# Altium schematic sheet Tiresias_PMIC.SchDoc
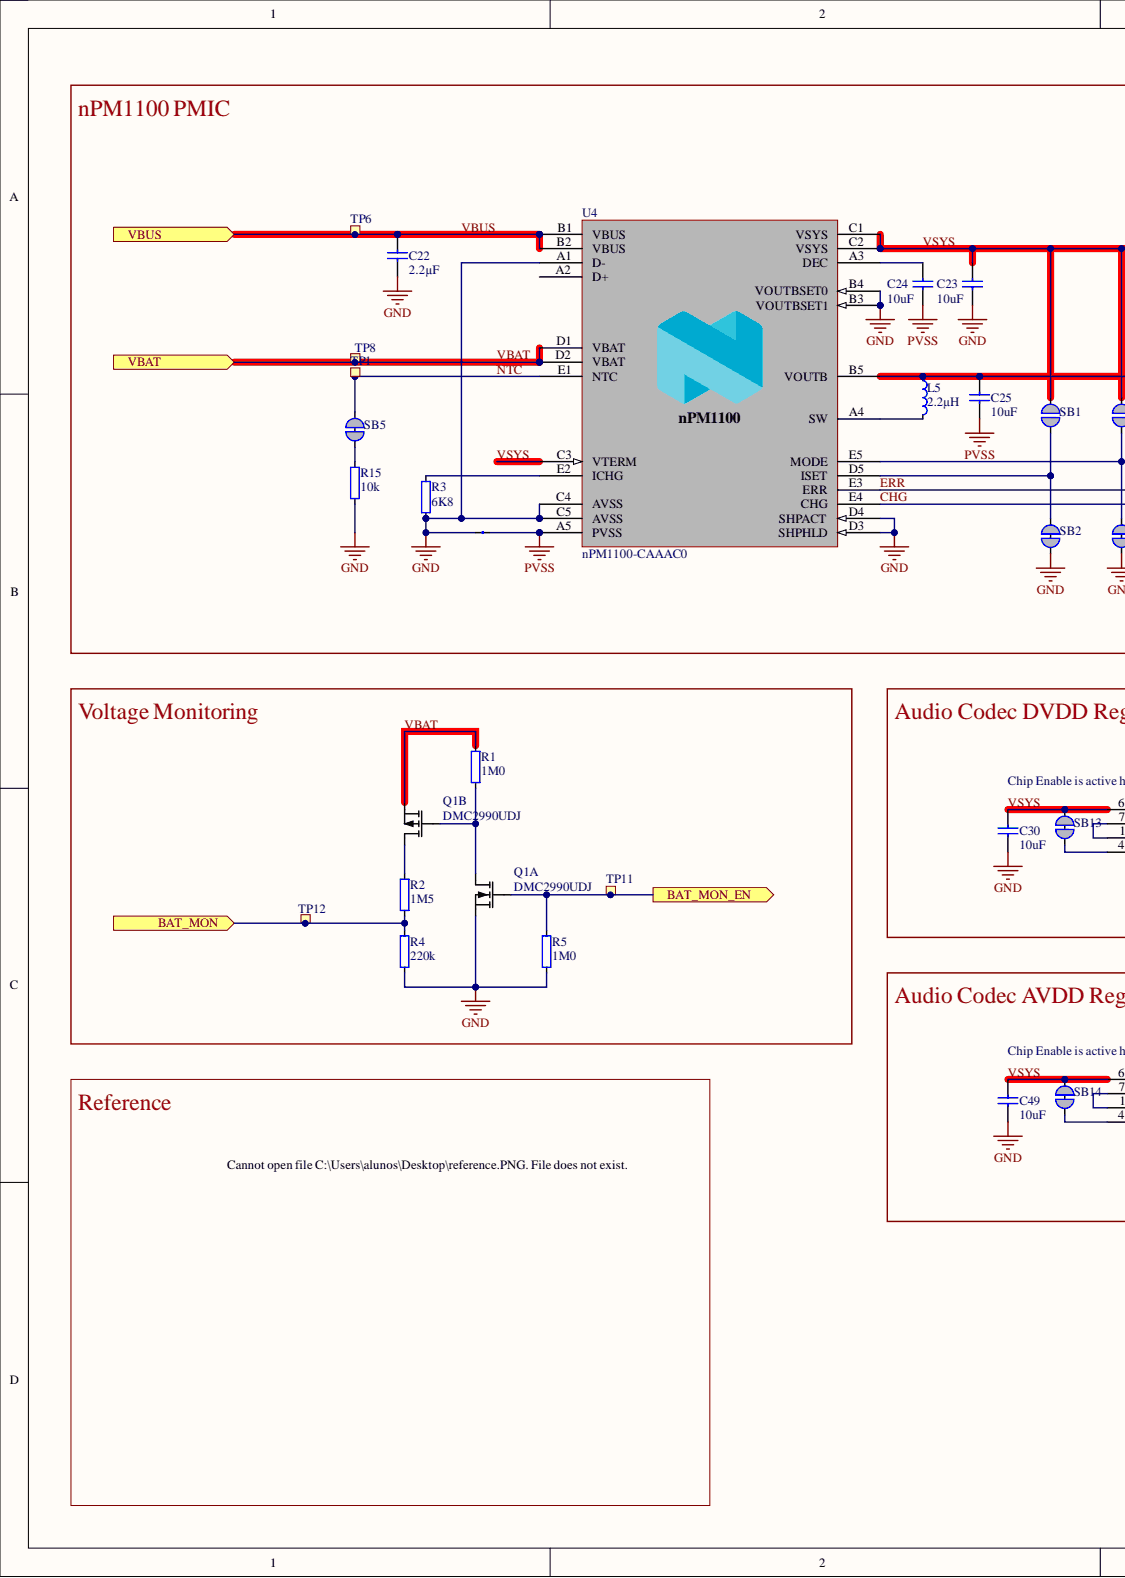
[diagram p0: Tiresias_PMIC.SchDoc, left]
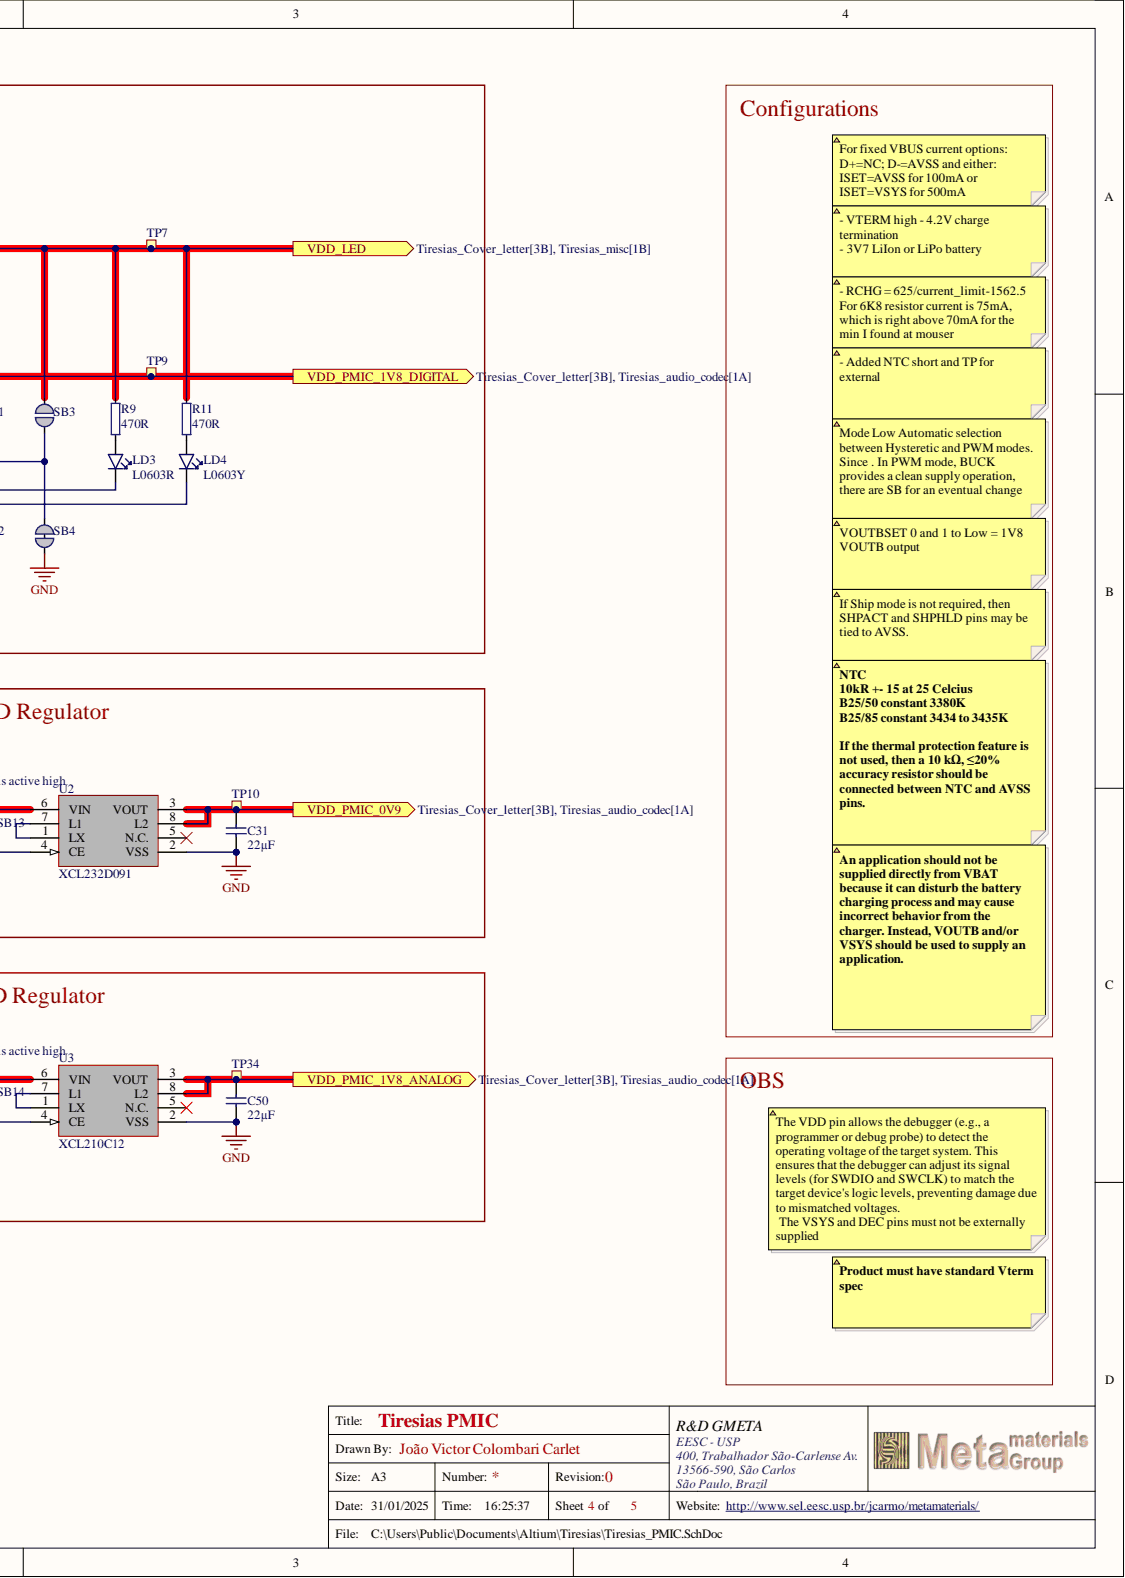
[diagram p1: Tiresias_PMIC.SchDoc, right]

## Parts
C22  2.2µF  [CAPC1608X06L]
C23  10uF  [CAPC1608X06L]
C24  10uF  [CAPC1608X06L]
C25  10uF  [CAPC1608X06L]
C30  10uF  [CAPC1608X06L]
C31  22µF  [CAPC1608X06L]
C49  10uF  [CAPC1608X06L]
C50  22µF  [CAPC1608X06L]
J6  N.A.  [2 PIN STARPOINT]  (value not drawn)
L5  2.2µH  [INDC2016X10N]
LD3  L0603R  [LED_0603_R]
LD4  L0603Y  [LED_0603_Y]
Q1  DMC2990UDJ  [SOTFL35P100X50-6N]  (parts A,B)
R1  1M0  [RESC0603X03L_C]
R2  1M5  [RESC0603X03L_C]
R3  6K8  [RESC0603X03L_C]
R4  220k  [RESC0603X03L_C]
R5  1M0  [RESC0603X03L_C]
R9  470R  [RESC0603X03L_C]
R11  470R  [RESC0603X03L_C]
R15  10k  [RESC0603X03L_C]
SB1  Solderbridge  [Solderbridge]  (value not drawn)  (no BOM)
SB2  Solderbridge  [Solderbridge_Shorted]  (value not drawn)
SB3  Solderbridge  [Solderbridge]  (value not drawn)  (no BOM)
SB4  Solderbridge  [Solderbridge_Shorted]  (value not drawn)
SB5  Solderbridge  [Solderbridge_Shorted]  (value not drawn)
SB13  Solderbridge  [Solderbridge_Shorted]  (value not drawn)
SB14  Solderbridge  [Solderbridge_Shorted]  (value not drawn)
TP1  Ø1.5mm,SMD  [TP_SMD_D1.5mm]  (value not drawn)  (no BOM)
TP6  Ø1.5mm,SMD  [TP_SMD_D1.5mm]  (value not drawn)  (no BOM)
TP7  Ø1.5mm,SMD  [TP_SMD_D1.5mm]  (value not drawn)  (no BOM)
TP8  Ø1.5mm,SMD  [TP_SMD_D1.5mm]  (value not drawn)  (no BOM)
TP9  Ø1.5mm,SMD  [TP_SMD_D1.5mm]  (value not drawn)  (no BOM)
TP10  Ø1.5mm,SMD  [TP_SMD_D1.5mm]  (value not drawn)  (no BOM)
TP11  Ø1.0mm,SMD  [TP_SMD_D1.0mm]  (value not drawn)  (no BOM)
TP12  Ø1.0mm,SMD  [TP_SMD_D1.0mm]  (value not drawn)  (no BOM)
TP34  Ø1.5mm,SMD  [TP_SMD_D1.5mm]  (value not drawn)  (no BOM)
U2  XCL232D091  [CL-2025]
U3  XCL210C12  [CL-2025]
U4  nPM1100-CAAAC0  [BGA25C40P5X5_200X200X50]

## Ports
BAT_MON, BAT_MON_EN, VBAT, VBUS, VDD_LED, VDD_PMIC_0V9, VDD_PMIC_1V8_ANALOG, VDD_PMIC_1V8_DIGITAL

## Nets
GND: C22.1 C23.1 C30.1 C31.1 C49.1 C50.1 J6.1 Q1.1 R3.2 R4.1 R5.1 R15.1 SB2.2 SB4.2 U2.2(VSS) U2.9 U3.2(VSS) U3.9 U4.A1(D-) U4.B3(VOUTBSET1) U4.B4(VOUTBSET0) U4.C4(AVSS) U4.C5(AVSS) U4.D3(SHPHLD) U4.D4(SHPACT)
PVSS: C24.1 C25.2 J6.2 U4.A5(PVSS)
BAT_MON: R2.1 R4.2 TP12.1 ; port BAT_MON
BAT_MON_EN: Q1.2 R5.2 TP11.1 ; port BAT_MON_EN
CHG: LD4.1 U4.E4(CHG)
ERR: LD3.1 U4.E3(ERR)
NTC: SB5.1 TP1.1 U4.E1(NTC)
VBAT: Q1.4 R1.2 TP8.1 U4.D1(VBAT) U4.D2(VBAT) ; port VBAT
VBUS: C22.2 TP6.1 U4.B1(VBUS) U4.B2(VBUS) ; port VBUS
VDD_PMIC_0V9: C31.2 TP10.1 U2.3(VOUT) U2.8(L2) ; port VDD_PMIC_0V9
VDD_PMIC_1V8_ANALOG: C50.2 TP34.1 U3.3(VOUT) U3.8(L2) ; port VDD_PMIC_1V8_ANALOG
VDD_PMIC_1V8_DIGITAL: C25.1 L5.1 TP9.1 U4.B5(VOUTB) ; port VDD_PMIC_1V8_DIGITAL
VSYS: C23.2 C30.2 C49.2 R9.2 R11.2 SB1.1 SB3.1 SB13.1 SB14.1 TP7.1 U2.6(VIN) U3.6(VIN) U4.C1(VSYS) U4.C2(VSYS) U4.C3(VTERM) ; port VDD_LED
NetC24_2: C24.2 U4.A3(DEC)
NetL5_2: L5.2 U4.A4(SW)
NetLD3_2: LD3.2 R9.1
NetLD4_2: LD4.2 R11.1
NetQ1_3: Q1.3 R2.2
NetQ1_5: Q1.5 Q1.6 R1.1
NetR3_1: R3.1 U4.E2(ICHG)
NetR15_2: R15.2 SB5.2
NetSB1_2: SB1.2 SB2.1 U4.D5(ISET)
NetSB3_2: SB3.2 SB4.1 U4.E5(MODE)
NetSB13_2: SB13.2 U2.4(CE)
NetSB14_2: SB14.2 U3.4(CE)
NetU2_1: U2.1(LX) U2.7(L1)
NetU2_5: U2.5(N.C.)
NetU3_1: U3.1(LX) U3.7(L1)
NetU3_5: U3.5(N.C.)
NetU4_A2: U4.A2(D+)

## Library data of the parts
C22 = CAP_0603_2u2F: Capacitor, Ceramic, X5R, ±10%, 10V; Part Number: C0603-2µ2-10V-X5R; Artikkelnr Noca: N.A.; Pad Count: 2; Component Class: Capacitors; Component Kind: Standard; ComponentLink1Description: Datasheet; ComponentLink1URL: N.A.; ComponentLink2Description: Supplier link; ComponentLink2URL: N.A.; Footprint Doc: 0603; HelpURL: N.A.; LatestRevisionDate: 2021-07-22; Manufacturer: N.A.; Manufacturer Part Number: N.A.; Note: 10V; Part number IPS: N.A.; Part number Norautron: N.A.; Published: 2021-07-22; Supplier 1: N.A.; Supplier 2: N.A.; Supplier Part Number 1: N.A.; Supplier Part Number 2: N.A.; Value: 2.2µF; Author: rubr; Library Name: Tiresias_passive.SchLib
C23-C25 C30 C49 = CAP_0603_10uF: Capacitor, X5R, ±10%; Part Number: C0603-10µ; Class: SMT; Component Kind: Standard; LatestRevisionDate: 2024/02/20; Package: 0603; Pin Count: 2; Value: 10uF; Library Name: Tiresias_passive.SchLib
C31 C50 = CAP_0603_22uF: Capacitor, X5R, ±20%, 6.3V; Part Number: C0603-22µ; Artikkelnr Noca: N.A.; Pad Count: 2; Component Class: Capacitors; Component Kind: Standard; ComponentLink1Description: Datasheet; ComponentLink1URL: N.A.; ComponentLink2Description: Supplier link; ComponentLink2URL: N.A.; Footprint Doc: 0603; HelpURL: N.A.; LatestRevisionDate: 2020-09-23; Manufacturer: N.A.; Manufacturer Part Number: N.A.; Note: 6.3V; Part number IPS: N.A.; Part number Norautron: N.A.; PartId: 100200276; Published: 2020-09-23; Supplier 1: N.A.; Supplier 2: N.A.; Supplier Part Number 1: N.A.; Supplier Part Number 2: N.A.; Value: 22µF; Author: rsk; Library Name: Tiresias_passive.SchLib
J6 = 2 pin starpoint: Starpoint; Pad Count: 2; Artikkelnr Noca: N.A.; Component Class: Connectors; Component Kind: Net Tie; ComponentLink1Description: Datasheet; ComponentLink1URL: N.A.; ComponentLink2Description: Supplier link; ComponentLink2URL: N.A.; Footprint Doc: N.A.; HelpURL: N.A.; LatestRevisionDate: 2017-08-31; Manufacturer: N.A.; Manufacturer Part Number: N.A.; Note: N.A.; Part Number: 2 pin starpoint; Part number IPS: N.A.; Part number Norautron: N.A.; PartId: 100500603; Published: 2017-08-31; Supplier 1: N.A.; Supplier 2: N.A.; Supplier Part Number 1: N.A.; Supplier Part Number 2: N.A.; Value: N.A.; Author: rsk; Library Name: Tiresias_passive.SchLib
L5 = IND_0806_2u2H: FIXED IND 2.2UH 2.5A 87MOHM SMD; Manufacturer: Samsung Electro-Mechanics; Manufacturer Part Number: CIGT201610EH2R2MNE; Artikkelnr Noca: N.A.; Note: 2.2µH Unshielded Thin Film Inductor 2.5A 87mOhm Max 0806 (2016 Metric); Pad Count: 2; Component Class: Inductors; Component Kind: Standard; ComponentLink1Description: Datasheet; ComponentLink1URL: https://media.digikey.com/pdf/Data%20Sheets/Samsung%20PDFs/CIGT201610EH2R2MNE_Spec.pdf; ComponentLink2Description: Supplier link; ComponentLink2URL: https://www.digikey.com/en/products/detail/samsung-electro-mechanics/CIGT201610EH2R2MNE/7041223?s=N4IgTCBcDaIMIEkDiAVMAGAjANk%2BgogBJgBKYAsgHL4gC6AvkA; Footprint Doc: 0806; HelpURL: http://product.samsungsem.com/pi/CIGT201610EH2R2MN.do; LatestRevisionDate: 2021-02-03; Part Number: CIGT201610EH2R2MNE; Part number IPS: N.A.; Part number Norautron: N.A.; PartId: 100300400; Published: 2021-02-03; Supplier 1: Digi-Key; Supplier 2: Mouser; Supplier Part Number 1: 1276-6901-1-ND; Supplier Part Number 2: 187-CIGT201610EH2R2M; Value: 2.2µH; Author: rsk; Library Name: Tiresias_passive.SchLib
LD3 = LED_0603_RED: LED, SMD, 0603, RED; Manufacturer: Multicomp; Part Number: OVS-0608 RED; Artikkelnr Noca: N.A.; Note: 100 mcd @ 20 mA, Super bright; Pad Count: 2; Component Class: Optoelectronics; Component Kind: Standard; ComponentLink1Description: Datasheet; ComponentLink1URL: N.A.; ComponentLink2Description: Supplier link; ComponentLink2URL: N.A.; Footprint Doc: 0603; HelpURL: http://www.farnell.com/datasheets/1673654.pdf; LatestRevisionDate: 2011-10-28; Manufacturer Part Number: OVS-0608; Part number IPS: N.A.; Part number Norautron: N.A.; PartId: 101400024; Published: 2011-10-28; Supplier 1: Farnell; Supplier 2: N.A.; Supplier Part Number 1: 171-6774; Supplier Part Number 2: N.A.; Value: L0603R; Author: rsk; Library Name: Tiresias_passive.SchLib
LD4 = LED_0603_YEL: LED, Yellow, 0603, 590nm, Vf=2.0V, 120mcd, - 40 to +85°C; Manufacturer: Wurth Elektronik; Manufacturer Part Number: 150060YS75000; Artikkelnr Noca: N.A.; Pad Count: 2; Component Class: Optoelectronics; Component Kind: Standard; ComponentLink1Description: Datasheet; ComponentLink1URL: https://katalog.we-online.de/led/datasheet/150060YS75000.pdf; ComponentLink2Description: Supplier link; ComponentLink2URL: https://www.digikey.com/en/products/detail/w%C3%BCrth-elektronik/150060YS75000/4489909; Footprint Doc: 0603; HelpURL: N.A.; LatestRevisionDate: 2020-11-04; Note: N.A.; Part Number: 150060YS75000; Part number IPS: N.A.; Part number Norautron: N.A.; PartId: 101400136; Published: 2020-11-04; Supplier 1: Digi-Key; Supplier 2: N.A.; Supplier Part Number 1: 732-4981-1-ND; Supplier Part Number 2: N.A.; Value: L0603Y; Author: rsk; Library Name: Tiresias_passive.SchLib
Q1 = DMC2990UDJ-7: MOSFET, Dual N and P-Channel, 20V, 450mA/310mA, 990mOhm, 350mW; Manufacturer: Diodes Incorporated; Part number IPS: 00000004489; Artikkelnr Noca: N.A.; Pad Count: 6; Component Class: Semiconductors; Component Kind: Standard; ComponentLink1Description: Datasheet; ComponentLink1URL: https://www.diodes.com/assets/Datasheets/DMC2990UDJ.pdf; ComponentLink2Description: Supplier link; ComponentLink2URL: N.A.; Footprint Doc: SOT-963; HelpURL: N.A.; LatestRevisionDate: 2020-04-28; Manufacturer Part Number: DMC2990UDJ-7; Note: N.A.; Part Number: DMC2990UDJ-7; Part number Norautron: N.A.; PartId: 101300174; Published: 2020-02-21; Supplier 1: Digi-Key; Supplier 2: N.A.; Supplier Part Number 1: DMC2990UDJ-7DICT-ND; Supplier Part Number 2: N.A.; Value: DMC2990UDJ; Author: rsk; Library Name: Tiresias_active.SchLib
R1 R5 = RES_0201_1M0: Resistor, ±1%, 0.05W; Manufacturer: N.A.; Part Number: R0201-100k; Class: SMT; Component Kind: Standard; ComponentLink1Description: Datasheet; ComponentLink1URL: N.A.; ComponentLink2Description: Supplier link; ComponentLink2URL: N.A.; DigiKey link: 00000001419; JLCpcb link: Chip Res 1%; Latest Revision Date: 2024-04-23; Mouser link: N.A.; Package: 0201; Pin Count: 2; Value: 1M0; Author: rsk; Library Name: Tiresias_passive.SchLib
R2 = RES_0201_1M5: Resistor, ±1%, 0.05W; Manufacturer: N.A.; Part Number: R0201-100k; Class: SMT; Component Kind: Standard; ComponentLink1Description: Datasheet; ComponentLink1URL: N.A.; ComponentLink2Description: Supplier link; ComponentLink2URL: N.A.; DigiKey link: 00000001419; JLCpcb link: Chip Res 1%; Latest Revision Date: 2024-04-23; Mouser link: N.A.; Package: 0201; Pin Count: 2; Value: 1M5; Author: rsk; Library Name: Tiresias_passive.SchLib
R3 = RES_0201_6K8: Resistor, ±1%, 0.05W; Manufacturer: N.A.; Part Number: R0201-100k; Class: SMT; Component Kind: Standard; ComponentLink1Description: Datasheet; ComponentLink1URL: N.A.; ComponentLink2Description: Supplier link; ComponentLink2URL: N.A.; DigiKey link: 00000001419; JLCpcb link: Chip Res 1%; Latest Revision Date: 2024-04-23; Mouser link: N.A.; Package: 0201; Pin Count: 2; Value: 6K8; Author: rsk; Library Name: Tiresias_passive.SchLib
R4 = RES_0201_220K: Resistor, ±1%, 0.05W; Manufacturer: N.A.; Part Number: R0201-100k; Class: SMT; Component Kind: Standard; ComponentLink1Description: Datasheet; ComponentLink1URL: N.A.; ComponentLink2Description: Supplier link; ComponentLink2URL: N.A.; DigiKey link: 00000001419; JLCpcb link: Chip Res 1%; Latest Revision Date: 2024-04-23; Mouser link: N.A.; Package: 0201; Pin Count: 2; Value: 220k; Author: rsk; Library Name: Tiresias_passive.SchLib
R9 R11 = RES_0201_470R: Resistor, ±1%, 0.05W; Part Number: R0201-470; Artikkelnr Noca: N.A.; Note: Chip Res 1%; Pad Count: 2; Component Class: Resistors; Component Kind: Standard; ComponentLink1Description: Datasheet; ComponentLink1URL: N.A.; ComponentLink2Description: Supplier link; ComponentLink2URL: N.A.; Footprint Doc: 0201; HelpURL: N.A.; LatestRevisionDate: 2014-11-13; Manufacturer: N.A.; Manufacturer Part Number: N.A.; Part number IPS: N.A.; Part number Norautron: N.A.; PartId: 100100037; Published: 2014-11-13; Supplier 1: N.A.; Supplier 2: N.A.; Supplier Part Number 1: N.A.; Supplier Part Number 2: N.A.; Value: 470R; Author: rsk; Library Name: Tiresias_passive.SchLib
R15 = RES_0201_10K: Resistor, ±1%, 0.05W; Manufacturer: N.A.; Part Number: R0201-100k; Class: SMT; Component Kind: Standard; ComponentLink1Description: Datasheet; ComponentLink1URL: N.A.; ComponentLink2Description: Supplier link; ComponentLink2URL: N.A.; DigiKey link: 00000001419; JLCpcb link: Chip Res 1%; Latest Revision Date: 2024-04-23; Mouser link: N.A.; Package: 0201; Pin Count: 2; Value: 10k; Author: rsk; Library Name: Tiresias_passive.SchLib
SB1 SB3 = Solderbridge: Artikkelnr Noca: N.A.; Pad Count: 2; Component Class: Connectors; ComponentLink1Description: Datasheet; ComponentLink1URL: N.A.; ComponentLink2Description: Supplier link; ComponentLink2URL: N.A.; Description: Solderbridge; Footprint Doc: Solderbridge; HelpURL: N.A.; LatestRevisionDate: 2009-12-14; Manufacturer: N.A.; Manufacturer Part Number: N.A.; Note: N.A.; Part Number: Solderbridge; Part number IPS: N.A.; Part number Norautron: N.A.; PartId: 100500458; Published: 2009-12-14; Supplier 1: N.A.; Supplier 2: N.A.; Supplier Part Number 1: N.A.; Supplier Part Number 2: N.A.; Value: Solderbridge; Author: rsk; Library Name: Tiresias_mechanical.SchLib
SB2 SB4 SB5 SB13 SB14 = Solderbridge Shorted: Shorted Solderbridge; Artikkelnr Noca: N.A.; Pad Count: 2; Component Class: Connectors; Component Kind: Net Tie; ComponentLink1Description: Datasheet; ComponentLink1URL: N.A.; ComponentLink2Description: Supplier link; ComponentLink2URL: N.A.; Footprint Doc: Solderbridge_Shorted; HelpURL: N.A.; LatestRevisionDate: 2012-08-07; Manufacturer: N.A.; Manufacturer Part Number: N.A.; Note: N.A.; Part Number: Solderbridge Shorted; Part number IPS: N.A.; Part number Norautron: N.A.; PartId: 100500459; Published: 2012-08-07; Supplier 1: N.A.; Supplier 2: N.A.; Supplier Part Number 1: N.A.; Supplier Part Number 2: N.A.; Value: Solderbridge; Author: rsk; Library Name: Tiresias_mechanical.SchLib
TP1 TP6-TP10 TP34 = TP_SMD_Ø1.5MM: 1.5mm circular SMD testpad; Manufacturer: Nordic Semiconductor; Artikkelnr Noca: N.A.; Pad Count: 1; Component Class: Connectors; ComponentLink1Description: Datasheet; ComponentLink1URL: N.A.; ComponentLink2Description: Supplier link; ComponentLink2URL: N.A.; Footprint Doc: TP_SMD_Ø1.5mm; HelpURL: N.A.; LatestRevisionDate: 2021-03-05; Manufacturer Part Number: N.A.; Note: N.A.; Part Number: TP_SMD_Ø1.5MM; Part number IPS: N.A.; Part number Norautron: N.A.; PartId: 100500477; Published: 2016-06-02; Supplier 1: N.A.; Supplier 2: N.A.; Supplier Part Number 1: N.A.; Supplier Part Number 2: N.A.; Value: Ø1.5mm,SMD; Author: rsk; Library Name: Tiresias_mechanical.SchLib
TP11 TP12 = TP_SMD_Ø1.0MM: 1.0mm circular SMD testpad; Manufacturer: Nordic Semiconductor; Artikkelnr Noca: N.A.; Pad Count: 1; Component Class: Connectors; ComponentLink1Description: Datasheet; ComponentLink1URL: N.A.; ComponentLink2Description: Supplier link; ComponentLink2URL: N.A.; Footprint Doc: TP_SMD_Ø1.0mm; HelpURL: N.A.; LatestRevisionDate: 2021-03-05; Manufacturer Part Number: N.A.; Note: N.A.; Part Number: TP_SMD_Ø1.0MM; Part number IPS: N.A.; Part number Norautron: N.A.; PartId: 100500475; Published: 2013-06-11; Supplier 1: N.A.; Supplier 2: N.A.; Supplier Part Number 1: N.A.; Supplier Part Number 2: N.A.; Value: Ø1.0mm,SMD; Author: rsk; Library Name: Tiresias_mechanical.SchLib
U2 = XCL232D091KR-G: 0.9V Ultra-Low Quiescent Current, Inductor Built-in Step-Down DC/DC Converter, CL-2025-03   Source:  Datasheet; Manufacturer: Torex Semiconductor; Manufacturer Part Number: XCL210C121GR-G; Part Number: XCL210C12; Artikkelnr Noca: N.A.; Pad Count: 8; Component Class: Misc_ICs; Component Kind: Standard; ComponentLink1Description: Datasheet; ComponentLink1URL: https://www.torexsemi.com/file/xcl210/XCL210.pdf; ComponentLink2Description: Supplier link; ComponentLink2URL: https://www.digikey.com/en/products/detail/torex-semiconductor-ltd/XCL210C121GR-G/5964723; Digikey Link: https://www.digikey.com/en/products/detail/torex-semiconductor-ltd/XCL210D201GR-G/10148097?s=N4IgTCBcDaIBoGEAyYCMAGAImdqDiASgLR4gC6AvkA; Footprint Doc: CL-2025; HelpURL: https://www.torexsemi.com/products/built-in-dcdc-converters/series/?name=xcl210; LatestRevisionDate: 2021-04-22; Mouser Link: https://au.mouser.com/ProductDetail/Torex-Semiconductor/XCL210D201GR-G?qs=AsjdqWjXhJ%252Bfq4YmN5RHyA%3D%3D&_gl=1*8wexd*_ga*MTYyMjg1NzA0OC4xNzEzODc4NTc0*_ga_15W4STQT4T*MTcxMzk3OTMxMS4zLjAuMTcxMzk3OTMxMi41OS4wLjA.; Note: N.A.; Part number IPS: N.A.; Part number Norautron: N.A.; PartId: 101900655; Published: 2021-04-22; Supplier 1: Digi-Key; Supplier 2: Mouser; Supplier Part Number 1: 893-1249-1-ND; Supplier Part Number 2: 865-XCL210C121GR-G; Value: XCL232D091; Author: rubr; Library Name: Tiresias_active.SchLib
U3 = XCL210C18: 1.8V, 200mA Inductor Built-in Step-Down “micro DC/DC” Converter; Manufacturer: Torex Semiconductor; Manufacturer Part Number: XCL210C121GR-G; Artikkelnr Noca: N.A.; Pad Count: 8; Component Class: Misc_ICs; Component Kind: Standard; ComponentLink1Description: Datasheet; ComponentLink1URL: https://www.torexsemi.com/file/xcl210/XCL210.pdf; ComponentLink2Description: Supplier link; ComponentLink2URL: https://www.digikey.com/en/products/detail/torex-semiconductor-ltd/XCL210C121GR-G/5964723; Digikey Link: https://www.digikey.com/en/products/detail/torex-semiconductor-ltd/XCL210D201GR-G/10148097?s=N4IgTCBcDaIBoGEAyYCMAGAImdqDiASgLR4gC6AvkA; Footprint Doc: CL-2025; HelpURL: https://www.torexsemi.com/products/built-in-dcdc-converters/series/?name=xcl210; LatestRevisionDate: 2021-04-22; Mouser Link: https://au.mouser.com/ProductDetail/Torex-Semiconductor/XCL210D201GR-G?qs=AsjdqWjXhJ%252Bfq4YmN5RHyA%3D%3D&_gl=1*8wexd*_ga*MTYyMjg1NzA0OC4xNzEzODc4NTc0*_ga_15W4STQT4T*MTcxMzk3OTMxMS4zLjAuMTcxMzk3OTMxMi41OS4wLjA.; Note: N.A.; Part Number: XCL210C12; Part number IPS: N.A.; Part number Norautron: N.A.; PartId: 101900655; Published: 2021-04-22; Supplier 1: Digi-Key; Supplier 2: Mouser; Supplier Part Number 1: 893-1249-1-ND; Supplier Part Number 2: 865-XCL210C121GR-G; Value: XCL210C12; Author: rubr; Library Name: Tiresias_active.SchLib
U4 = nPM1100-CAAAC0: Li-Ion/Li-Polymer USB battery charger with a high efficiency buck regulator; Manufacturer: Nordic Semiconductor; Artikkelnr Noca: N.A.; Pad Count: 25; Component Class: nRF_Devices; Component Kind: Standard; ComponentLink1Description: Datasheet; ComponentLink1URL: N.A.; ComponentLink2Description: Supplier link; ComponentLink2URL: N.A.; Footprint Doc: WLCSP-25; HelpURL: N.A.; LatestRevisionDate: 2021-04-21; Manufacturer Part Number: nPM1100-CAAAC0; Note: N.A.; Part Number: nPM1100-CAAAC0; Part number IPS: N.A.; Part number Norautron: N.A.; PartId: 102000225; Published: 2021-04-21; Supplier 1: Nordic Semiconductor; Supplier 2: N.A.; Supplier Part Number 1: N.A.; Supplier Part Number 2: N.A.; Value: nPM1100-CAAAC0; Author: rubr; Library Name: Tiresias_logic.SchLib
